# Altium schematic sheet AT Mega.SchDoc
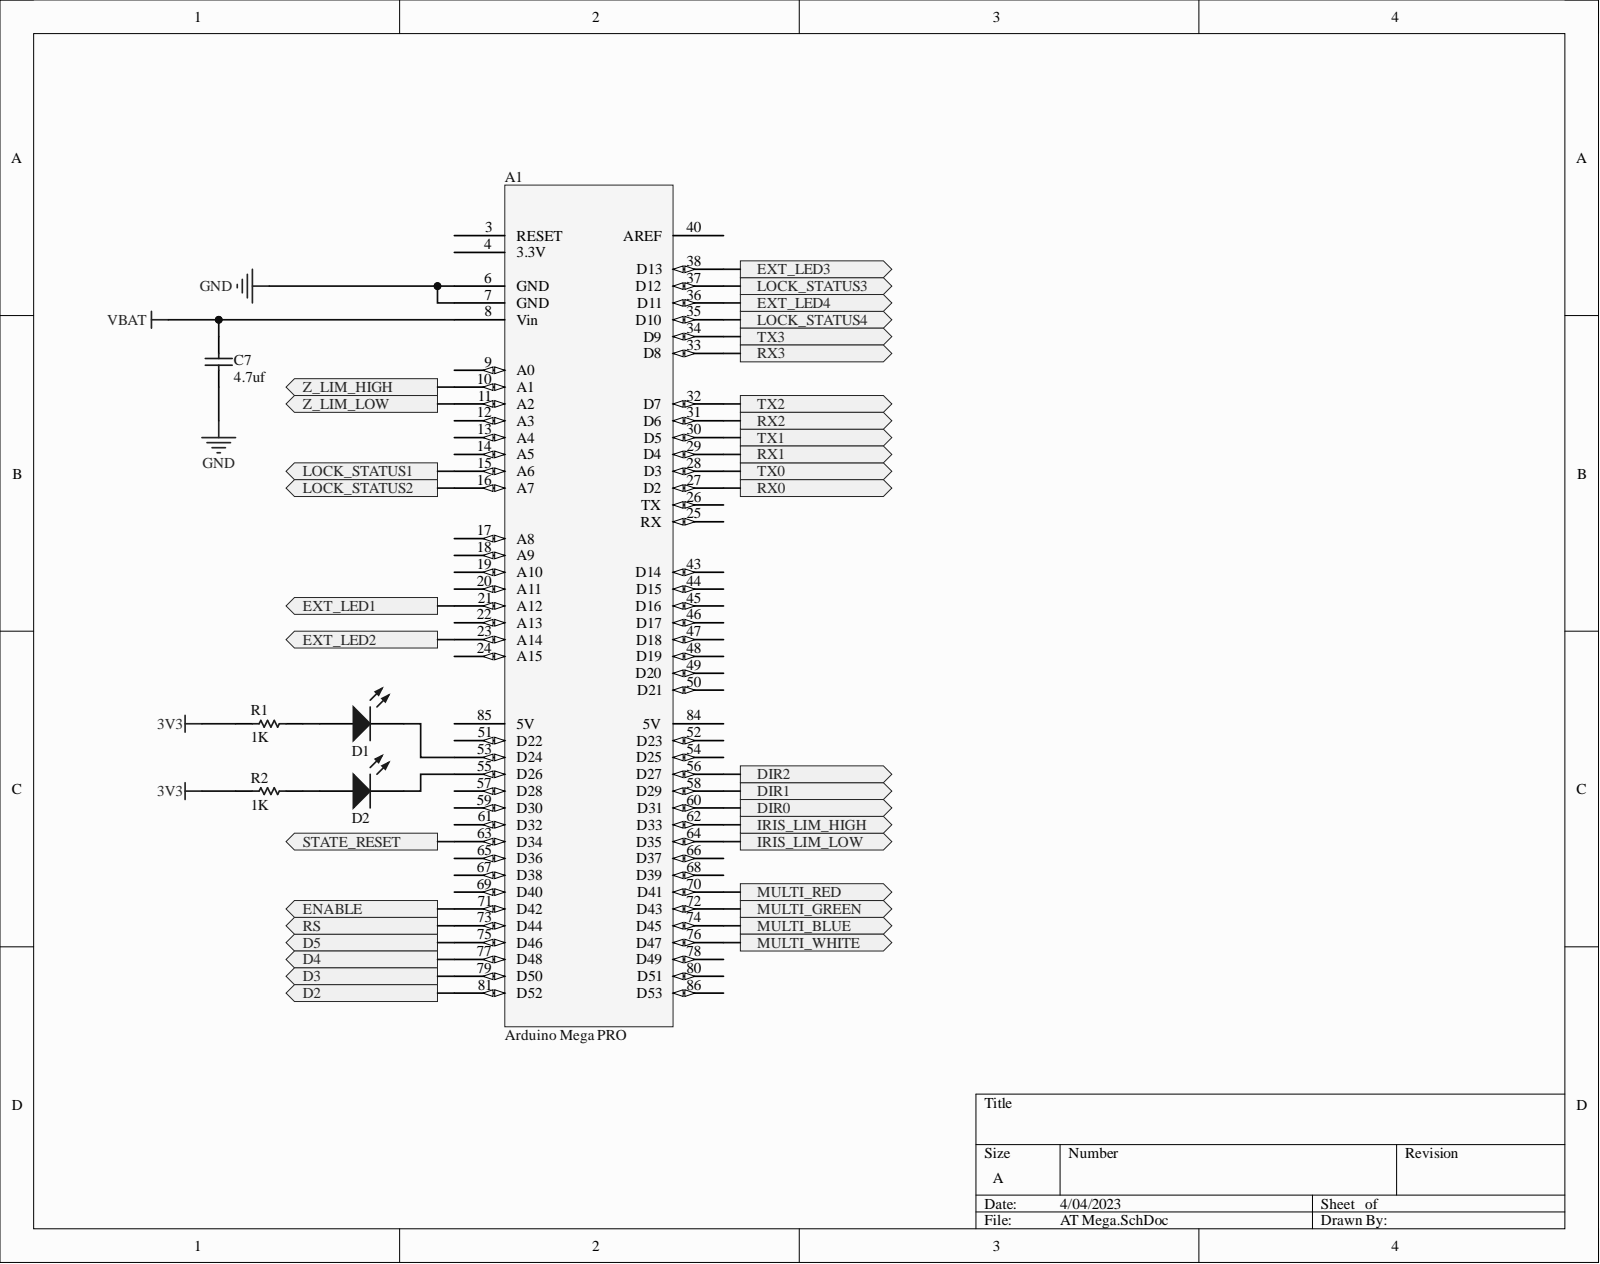
[diagram p0: AT Mega.SchDoc]

## Parts
A1  Arduino Mega PRO  [Arduino Mega PRO-Footprint-1]
C7  Capacitance: 4.7uf  [CAPC2013X94X50NL20T25]  (hidden comment: AVX Interconnect / Elco 08051C182KAT2A)
D1  Dialight 598-8191-107F  [PCB-8gfa86omdps060zikj8u-1]  (value not drawn)
D2  Dialight 598-8191-107F  [PCB-8gfa86omdps060zikj8u-1]  (value not drawn)
R1  Resistance: 1K  [RESC2013X70X40ML20T20]  (hidden comment: Panasonic ERJ-6GEYJ911V)
R2  Resistance: 1K  [RESC2013X70X40ML20T20]  (hidden comment: Panasonic ERJ-6GEYJ911V)

## Ports
D2, D3, D4, D5, DIR0, DIR1, DIR2, ENABLE, EXT_LED1, EXT_LED2, EXT_LED3, EXT_LED4, IRIS_LIM_HIGH, IRIS_LIM_LOW, LOCK_STATUS1, LOCK_STATUS2, LOCK_STATUS3, LOCK_STATUS4, MULTI_BLUE, MULTI_GREEN, MULTI_RED, MULTI_WHITE, RS, RX0, RX1, RX2, RX3, STATE_RESET, TX0, TX1, TX2, TX3, Z_LIM_HIGH, Z_LIM_LOW

## Nets
3V3: R1.1 R2.1
GND: A1.6(GND) A1.7(GND) C7.1
VBAT: A1.8(Vin) C7.2
D2: A1.81(D52) ; port D2
D3: A1.79(D50) ; port D3
D4: A1.77(D48) ; port D4
D5: A1.75(D46) ; port D5
DIR0: A1.60(D31) ; port DIR0
DIR1: A1.58(D29) ; port DIR1
DIR2: A1.56(D27) ; port DIR2
ENABLE: A1.71(D42) ; port ENABLE
EXT_LED1: A1.21(A12) ; port EXT_LED1
EXT_LED2: A1.23(A14) ; port EXT_LED2
EXT_LED3: A1.38(D13) ; port EXT_LED3
EXT_LED4: A1.36(D11) ; port EXT_LED4
IRIS_LIM_HIGH: A1.62(D33) ; port IRIS_LIM_HIGH
IRIS_LIM_LOW: A1.64(D35) ; port IRIS_LIM_LOW
LOCK_STATUS1: A1.15(A6) ; port LOCK_STATUS1
LOCK_STATUS2: A1.16(A7) ; port LOCK_STATUS2
LOCK_STATUS3: A1.37(D12) ; port LOCK_STATUS3
LOCK_STATUS4: A1.35(D10) ; port LOCK_STATUS4
MULTI_BLUE: A1.74(D45) ; port MULTI_BLUE
MULTI_GREEN: A1.72(D43) ; port MULTI_GREEN
MULTI_RED: A1.70(D41) ; port MULTI_RED
MULTI_WHITE: A1.76(D47) ; port MULTI_WHITE
RS: A1.73(D44) ; port RS
RX0: A1.27(D2) ; port RX0
RX1: A1.29(D4) ; port RX1
RX2: A1.31(D6) ; port RX2
RX3: A1.33(D8) ; port RX3
STATE_RESET: A1.63(D34) ; port STATE_RESET
TX0: A1.28(D3) ; port TX0
TX1: A1.30(D5) ; port TX1
TX2: A1.32(D7) ; port TX2
TX3: A1.34(D9) ; port TX3
Z_LIM_HIGH: A1.10 ; port Z_LIM_HIGH
Z_LIM_LOW: A1.11(A2) ; port Z_LIM_LOW
NetA1_53: A1.53(D24) D1.K
NetA1_55: A1.55(D26) D2.K
NetD1_A: D1.A R1.2
NetD2_A: D2.A R2.2

Not connected: A1 3(RESET) 4(3.3V) 9(A0) 12(A3) 13(A4) 14(A5) 17(A8) 18(A9) 19(A10) 20(A11) 22(A13) 24(A15) 25(RX) 26(TX) 40(AREF) 43(D14) 44(D15) 45(D16) 46(D17) 47(D18) 48(D19) 49(D20) 50(D21) 51(D22) 52(D23) 54(D25) 57(D28) 59(D30) 61(D32) 65(D36) 66(D37) 67(D38) 68(D39) 69(D40) 78(D49) 80(D51) 84(5V) 85(5V) 86(D53)

## Library data of the parts
A1 = CMP-f07f383c7eb42fb8-70: ATMEGA2560, ARDUINO MEGA2560 REV3, BOARD; Silicon Manufacturer: Atmel; Core Archi; Component Kind: Standard; Library Name: My Personal Space
C7 = CMP-128428-2: CAP CER 1800PF 100V 10% X7R 0805; Case/Package (SI): 2012; Lead-Free Status: Lead Free; Voltage Rating (DC): 100.0 V; Capacitance Tolerance: ±10%; Case/Package: 0805; Component Kind: Standard; Dielectric Characteristic: X7R; Library Name: My Personal Space; Manufacturer Part Number: 08051C182KAT2A; Number of Pins: 2; Octopart Url: https://octopart.com/08051c182kat2a-avx+interconnect+%2F+elco-2160389; OctopartID: 0d2cc406df4fd2eb; Packaging: Tape & Reel (TR); RoHS: Compliant
D1 D2 = CMP-90265921f4414fe1-1: 598 Series Blue 2 x 1.25 x 0.7 mm 140° Water Clear 140 mcd 3.2 V SMT LED; Case/Package (SI): 2012; Forward Voltage: 3.20 V; Lead-Free Status: Lead Free; Lens Type: Non-Diffused; Luminous Intensity: 140 mcd; Wavelength: 475 nm, 473 nm; Case/Package: 0805; Color: Blue; Component Kind: Standard; Library Name: My Personal Space; Manufacturer Part Number: 598-8191-107F; Mounting Style: Surface Mount; Number of Pins: 2; Octopart URL: http://octopart.com/598-8191-107f-dialight-868609; OctopartID: 90265921f4414fe1; Packaging: Reel; RoHS: Compliant; Size-Height: 700 µm; Size-Length: 2.00 mm; Size-Width: 1.25 mm; Viewing Angle: 140 °
R1 R2 = CMP-3670835-2: Thick Film Resistors - SMD 0805 910ohms 5% Tol; Case/Package (SI): 2012; Lead-Free Status: Lead Free; Power Rating: 0.125 W; Voltage Rating (DC): 150.0 V; Case/Package: 0805; Component Kind: Standard; Library Name: My Personal Space; Lifecycle Status: Not Listed by Manufacturer; Manufacturer Part Number: ERJ-6GEYJ911V; Mounting Style: Surface Mount; Octopart Url: https://octopart.com/erj-6geyj911v-panasonic-55423073; OctopartID: 40418a06d5469f86; Packaging: Cut Tape (CT); REACH SVHC Compliance: No SVHC; Resistance Tolerance: ±5%; RoHS: Compliant; Size-Height: 0.0006 m; Size-Length: 0.002 m; Size-Width: 0.00125 m
